# Altium schematic sheet [1]MainPCB.SchDoc
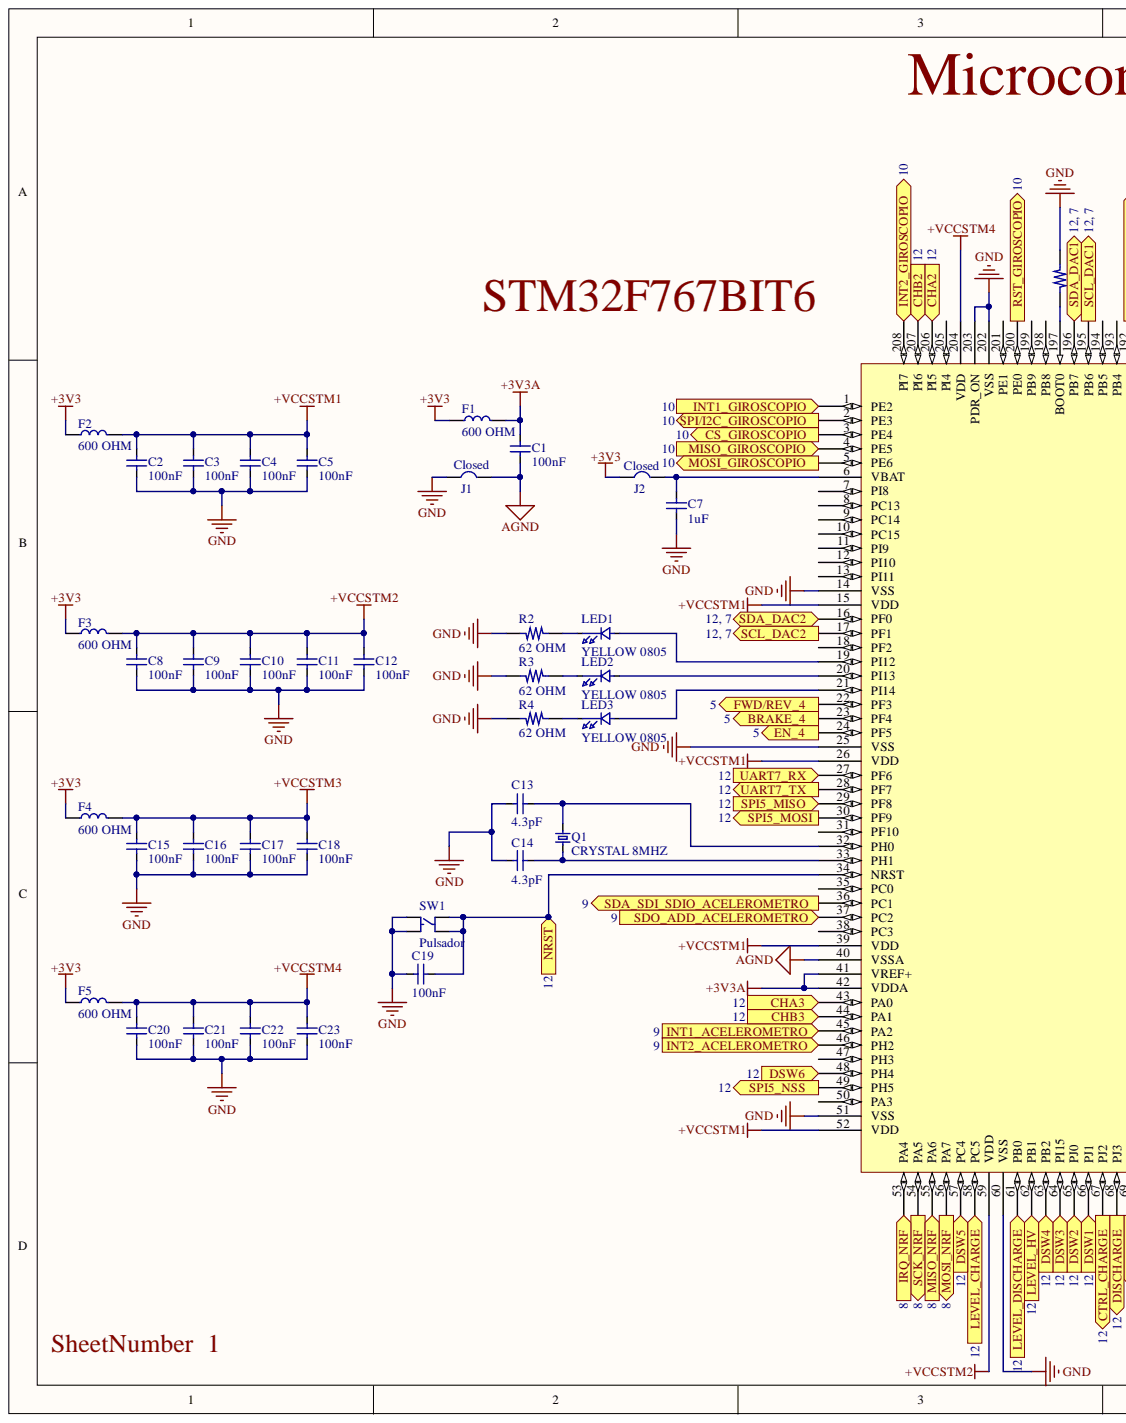
[diagram p0: [1]MainPCB.SchDoc, left]
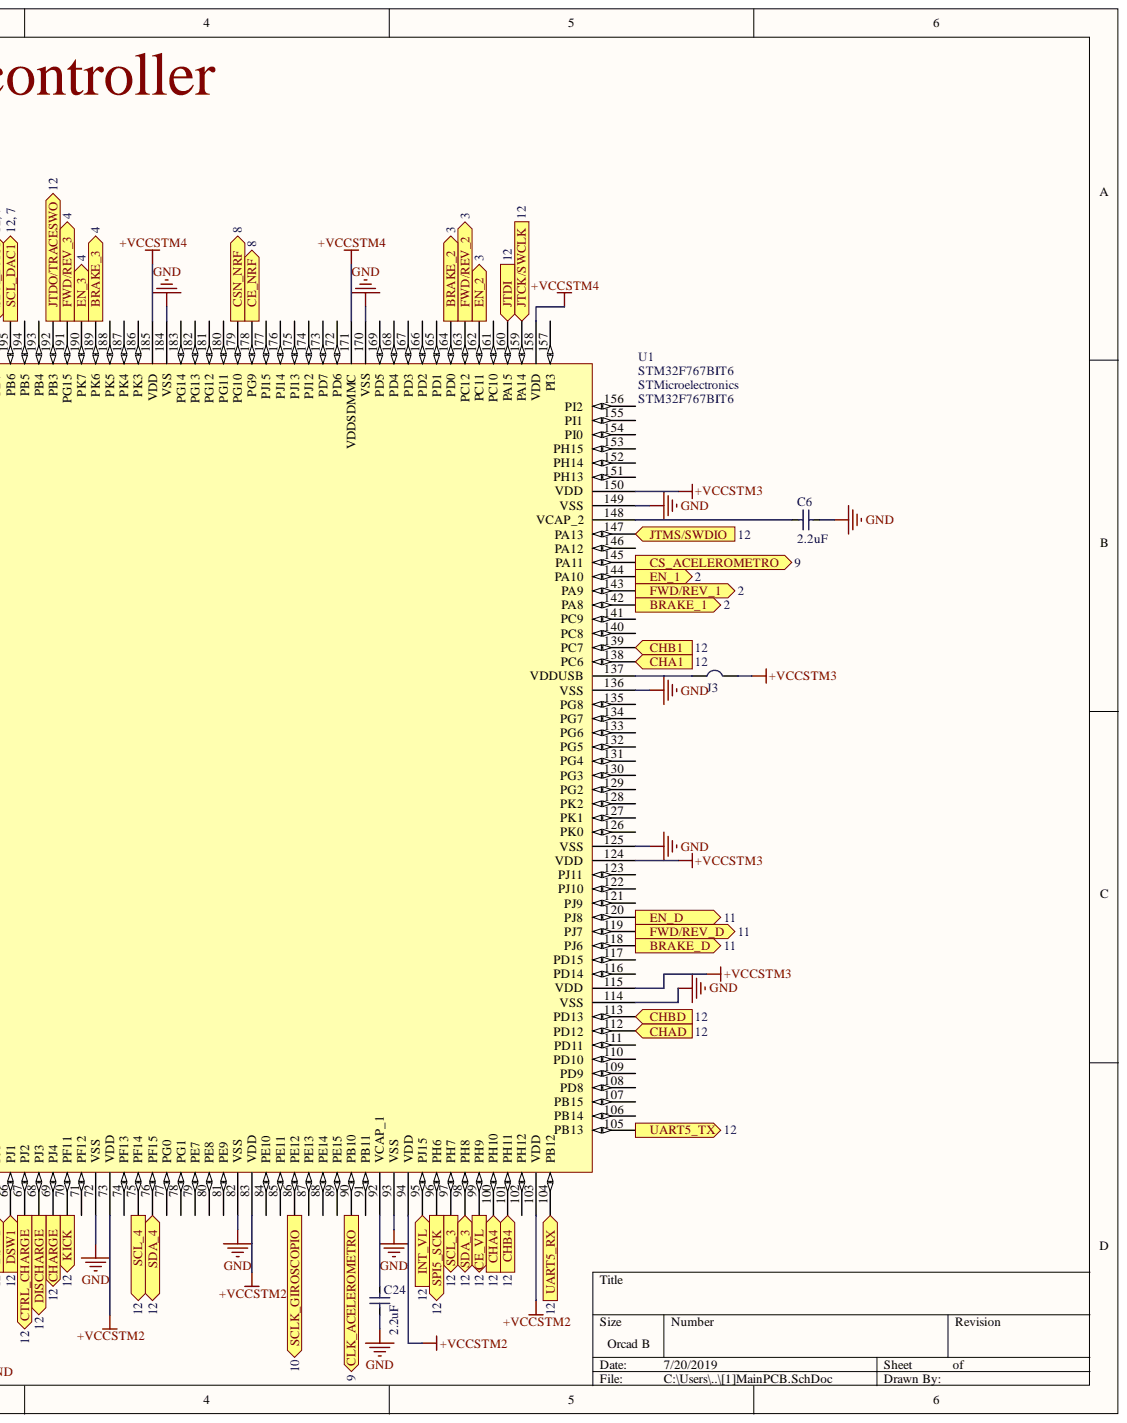
[diagram p1: [1]MainPCB.SchDoc, right]

## Parts
C1  100nF  [C0805]
C2  100nF  [C0805]
C3  100nF  [C0805]
C4  100nF  [C0805]
C5  100nF  [C0805]
C6  2.2uF  [C1206]
C7  1uF  [C0805]
C8  100nF  [C0805]
C9  100nF  [C0805]
C10  100nF  [C0805]
C11  100nF  [C0805]
C12  100nF  [C0805]
C13  4.3pF  [C0805]
C14  4.3pF  [C0805]
C15  100nF  [C0805]
C16  100nF  [C0805]
C17  100nF  [C0805]
C18  100nF  [C0805]
C19  100nF  [C0805]
C20  100nF  [C0805]
C21  100nF  [C0805]
C22  100nF  [C0805]
C23  100nF  [C0805]
C24  2.2uF  [C1206]
F1  600 OHM  [FB0805]
F2  600 OHM  [FB0805]
F3  600 OHM  [FB0805]
F4  600 OHM  [FB0805]
F5  600 OHM  [FB0805]
J1  Jumper  [Jumper]  (value not drawn)
J2  Jumper  [Jumper]  (value not drawn)
J3  Jumper  [Jumper]  (value not drawn)
LED1  YELLOW 0805  [LED0805]
LED2  YELLOW 0805  [LED0805]
LED3  YELLOW 0805  [LED0805]
Q1  CRYSTAL 8MHZ  [TXC 8MHZ Crystal]
R1  10k  [R0805]
R2  62 OHM  [R0805]
R3  62 OHM  [R0805]
R4  62 OHM  [R0805]
SW1  Pulsador  [SMT]
U1  STM32F767BIT6  Mfr 1: STMicroelectronics  [208LQFP]

## Ports
BRAKE_1, BRAKE_2, BRAKE_3, BRAKE_4, BRAKE_D, CE_NRF, CE_VL, CHA1, CHA2, CHA3, CHA4, CHAD, CHARGE, CHB1, CHB2, CHB3, CHB4, CHBD, CLK_ACELEROMETRO, CS_ACELEROMETRO, CS_GIROSCOPIO, CSN_NRF, CTRL_CHARGE, DISCHARGE, DSW1, DSW2, DSW3, DSW4, DSW5, DSW6, EN_1, EN_2, EN_3, EN_4, EN_D, FWD/REV_1, FWD/REV_2, FWD/REV_3, FWD/REV_4, FWD/REV_D, INT1_ACELEROMETRO, INT1_GIROSCOPIO, INT2_ACELEROMETRO, INT2_GIROSCOPIO, INT_VL, IRQ_NRF, JTCK/SWCLK, JTDI, JTDO/TRACESWO, JTMS/SWDIO, KICK, LEVEL_CHARGE, LEVEL_DISCHARGE, LEVEL_HV, MISO_GIROSCOPIO, MISO_NRF, MOSI_GIROSCOPIO, MOSI_NRF, NRST, RST_GIROSCOPIO, SCK_NRF, SCL_3, SCL_4, SCL_DAC1, SCL_DAC2, SCLK_GIROSCOPIO, SDA_3, SDA_4, SDA_DAC1, SDA_DAC2, SDA_SDI_SDIO_ACELEROMETRO, SDO_ADD_ACELEROMETRO, SPI5_MISO, SPI5_MOSI, SPI5_NSS, SPI5_SCK, SPI/I2C_GIROSCOPIO, UART5_RX, UART5_TX, UART7_RX, UART7_TX

## Nets
+3V3: F1.1 F2.1 F3.1 F4.1 F5.1 J2.1
+3V3A: C1.2 F1.2 U1.41(VREF+) U1.42(VDDA)
+VCCSTM1: C2.2 C3.2 C4.2 C5.2 F2.2 U1.15(VDD) U1.26(VDD) U1.39(VDD) U1.52(VDD)
+VCCSTM2: C8.2 C9.2 C10.2 C11.2 C12.2 F3.2 U1.59(VDD) U1.73(VDD) U1.83(VDD) U1.94(VDD) U1.103(VDD)
+VCCSTM3: C15.2 C16.2 C17.2 C18.2 F4.2 J3.2 U1.115(VDD) U1.124(VDD) U1.150(VDD)
+VCCSTM4: C20.2 C21.2 C22.2 C23.2 F5.2 U1.158(VDD) U1.171(VDDSDMMC) U1.185(VDD) U1.204(VDD)
AGND: C1.1 J1.2 U1.40(VSSA)
GND: C2.1 C3.1 C4.1 C5.1 C6.2 C7.1 C8.1 C9.1 C10.1 C11.1 C12.1 C13.1 C14.1 C15.1 C16.1 C17.1 C18.1 C19.1 C20.1 C21.1 C22.1 C23.1 C24.1 J1.1 R1.2 R2.1 R3.1 R4.1 SW1.1 SW1.2 U1.14(VSS) U1.25(VSS) U1.51(VSS) U1.60(VSS) U1.72(VSS) U1.82(VSS) U1.93(VSS) U1.114(VSS) U1.125(VSS) U1.136(VSS) U1.149(VSS) U1.170(VSS) U1.184(VSS) U1.202(VSS) U1.203(PDR_ON)
BRAKE_1: U1.142(PA8) ; port BRAKE_1
BRAKE_2: U1.164(PD0) ; port BRAKE_2
BRAKE_3: U1.189(PK6) ; port BRAKE_3
BRAKE_4: U1.23(PF4) ; port BRAKE_4
BRAKE_D: U1.118(PJ6) ; port BRAKE_D
CE_NRF: U1.178(PG9) ; port CE_NRF
CE_VL: U1.99(PH9) ; port CE_VL
CHA1: U1.138(PC6) ; port CHA1
CHA2: U1.206(PI5) ; port CHA2
CHA3: U1.43(PA0) ; port CHA3
CHA4: U1.100(PH10) ; port CHA4
CHAD: U1.112(PD12) ; port CHAD
CHARGE: U1.69(PJ4) ; port CHARGE
CHB1: U1.139(PC7) ; port CHB1
CHB2: U1.207(PI6) ; port CHB2
CHB3: U1.44(PA1) ; port CHB3
CHB4: U1.101(PH11) ; port CHB4
CHBD: U1.113(PD13) ; port CHBD
CLK_ACELEROMETRO: U1.90(PB10) ; port CLK_ACELEROMETRO
CS_ACELEROMETRO: U1.145(PA11) ; port CS_ACELEROMETRO
CS_GIROSCOPIO: U1.3(PE4) ; port CS_GIROSCOPIO
CSN_NRF: U1.179(PG10) ; port CSN_NRF
CTRL_CHARGE: U1.67(PJ2) ; port CTRL_CHARGE
DISCHARGE: U1.68(PJ3) ; port DISCHARGE
DSW1: U1.66(PJ1) ; port DSW1
DSW2: U1.65(PJ0) ; port DSW2
DSW3: U1.64(PI15) ; port DSW3
DSW4: U1.63(PB2) ; port DSW4
DSW5: U1.57(PC4) ; port DSW5
DSW6: U1.48(PH4) ; port DSW6
EN_1: U1.144(PA10) ; port EN_1
EN_2: U1.162(PC11) ; port EN_2
EN_3: U1.190(PK7) ; port EN_3
EN_4: U1.24(PF5) ; port EN_4
EN_D: U1.120(PJ8) ; port EN_D
FWD/REV_1: U1.143(PA9) ; port FWD/REV_1
FWD/REV_2: U1.163(PC12) ; port FWD/REV_2
FWD/REV_3: U1.191(PG15) ; port FWD/REV_3
FWD/REV_4: U1.22(PF3) ; port FWD/REV_4
FWD/REV_D: U1.119(PJ7) ; port FWD/REV_D
INT1_ACELEROMETRO: U1.45(PA2) ; port INT1_ACELEROMETRO
INT1_GIROSCOPIO: U1.1(PE2) ; port INT1_GIROSCOPIO
INT2_ACELEROMETRO: U1.46(PH2) ; port INT2_ACELEROMETRO
INT2_GIROSCOPIO: U1.208(PI7) ; port INT2_GIROSCOPIO
INT_VL: U1.95(PJ15) ; port INT_VL
IRQ_NRF: U1.53(PA4) ; port IRQ_NRF
JTCK/SWCLK: U1.159(PA14) ; port JTCK/SWCLK
JTDI: U1.160(PA15) ; port JTDI
JTDO/TRACESWO: U1.192(PB3) ; port JTDO/TRACESWO
JTMS/SWDIO: U1.147(PA13) ; port JTMS/SWDIO
KICK: U1.70(PF11) ; port KICK
LEVEL_CHARGE: U1.58(PC5) ; port LEVEL_CHARGE
LEVEL_DISCHARGE: U1.61(PB0) ; port LEVEL_DISCHARGE
LEVEL_HV: U1.62(PB1) ; port LEVEL_HV
MISO_GIROSCOPIO: U1.4(PE5) ; port MISO_GIROSCOPIO
MISO_NRF: U1.55(PA6) ; port MISO_NRF
MOSI_GIROSCOPIO: U1.5(PE6) ; port MOSI_GIROSCOPIO
MOSI_NRF: U1.56(PA7) ; port MOSI_NRF
NRST: C19.2 SW1.3 SW1.4 U1.34(NRST) ; port NRST
RST_GIROSCOPIO: U1.200(PE0) ; port RST_GIROSCOPIO
SCK_NRF: U1.54(PA5) ; port SCK_NRF
SCL_3: U1.97(PH7) ; port SCL_3
SCL_4: U1.75(PF14) ; port SCL_4
SCL_DAC1: U1.195(PB6) ; port SCL_DAC1
SCL_DAC2: U1.17(PF1) ; port SCL_DAC2
SCLK_GIROSCOPIO: U1.86(PE12) ; port SCLK_GIROSCOPIO
SDA_3: U1.98(PH8) ; port SDA_3
SDA_4: U1.76(PF15) ; port SDA_4
SDA_DAC1: U1.196(PB7) ; port SDA_DAC1
SDA_DAC2: U1.16(PF0) ; port SDA_DAC2
SDA_SDI_SDIO_ACELEROMETRO: U1.36(PC1) ; port SDA_SDI_SDIO_ACELEROMETRO
SDO_ADD_ACELEROMETRO: U1.37(PC2) ; port SDO_ADD_ACELEROMETRO
SPI5_MISO: U1.29(PF8) ; port SPI5_MISO
SPI5_MOSI: U1.30(PF9) ; port SPI5_MOSI
SPI5_NSS: U1.49(PH5) ; port SPI5_NSS
SPI5_SCK: U1.96(PH6) ; port SPI5_SCK
SPI/I2C_GIROSCOPIO: U1.2(PE3) ; port SPI/I2C_GIROSCOPIO
UART5_RX: U1.104(PB12) ; port UART5_RX
UART5_TX: U1.105(PB13) ; port UART5_TX
UART7_RX: U1.27(PF6) ; port UART7_RX
UART7_TX: U1.28(PF7) ; port UART7_TX
NetC6_1: C6.1 U1.148(VCAP_2)
NetC7_2: C7.2 J2.2 U1.6(VBAT)
NetC13_2: C13.2 Q1.2 U1.32(PH0)
NetC14_2: C14.2 Q1.1 U1.33(PH1)
NetC24_2: C24.2 U1.92(VCAP_1)
NetJ3_1: J3.1 U1.137(VDDUSB)
NetLED1_A: LED1.A U1.19(PI12)
NetLED1_K: LED1.K R2.2
NetLED2_A: LED2.A U1.20(PI13)
NetLED2_K: LED2.K R3.2
NetLED3_A: LED3.A U1.21(PI14)
NetLED3_K: LED3.K R4.2
NetR1_1: R1.1 U1.197(BOOT0)

Not connected: U1 7(PI8) 8(PC13) 9(PC14) 10(PC15) 11(PI9) 12(PI10) 13(PI11) 18(PF2) 31(PF10) 35(PC0) 38(PC3) 47(PH3) 50(PA3) 71(PF12) 74(PF13) 77(PG0) 78(PG1) 79(PE7) 80(PE8) 81(PE9) 84(PE10) 85(PE11) 87(PE13) 88(PE14) 89(PE15) 91(PB11) 102(PH12) 106(PB14) 107(PB15) 108(PD8) 109(PD9) 110(PD10) 111(PD11) 116(PD14) 117(PD15) 121(PJ9) 122(PJ10) 123(PJ11) 126(PK0) 127(PK1) 128(PK2) 129(PG2) 130(PG3) 131(PG4) 132(PG5) 133(PG6) 134(PG7) 135(PG8) 140(PC8) 141(PC9) 146(PA12) 151(PH13) 152(PH14) 153(PH15) 154(PI0) 155(PI1) 156(PI2) 157(PI3) 161(PC10) 165(PD1) 166(PD2) 167(PD3) 168(PD4) 169(PD5) 172(PD6) 173(PD7) 174(PJ12) 175(PJ13) 176(PJ14) 177(PJ15) 180(PG11) 181(PG12) 182(PG13) 183(PG14) 186(PK3) 187(PK4) 188(PK5) 193(PB4) 194(PB5) 198(PB8) 199(PB9) 201(PE1) 205(PI4)

## Library data of the parts
C1-C5 C8-C12 C15-C23 = CC0805ZRY5V9BB104: CAP CER 0.1UF 50V Y5V 0805; Mfr 1: Yageo; Component Kind: Standard; Library Name: Components.SchLib; Mfr 1 PN: CC0805ZRY5V9BB104
C6 C24 = CC1206KKX7R7BB225: CAP CER 2.2UF 16V X7R 1206; Mfr 1: Yageo; Component Kind: Standard; Library Name: Components.SchLib; Mfr 1 PN: CC1206KKX7R7BB225
C7 = UMK212BJ105KG-T: CAP CER 1UF 50V X5R 0805; Mfr 1: Taiyo Yuden; Component Kind: Standard; Library Name: Components.SchLib; Mfr 1 PN: UMK212BJ105KG-T
C13 C14 = 08051A4R3CAT2A: CAP CER 4.3PF 100V NP0 0805; Mfr 1: AVX Corporation; Component Kind: Standard; Library Name: Components.SchLib; Mfr 1 PN: 08051A4R3CAT2A
F1-F5 = MH2029-601Y: FERRITE BEAD 600 OHM 0805 1LN; Mfr 1: Bourns Inc.; Component Kind: Standard; Library Name: Components.SchLib; Mfr 1 PN: MH2029-601Y
LED1-LED3 = APT2012YC: LED YELLOW CLEAR CHIP SMD; Mfr 1: Kingbright; Component Kind: Standard; Library Name: Components.SchLib; Mfr 1 PN: APT2012YC
Q1 = AA-8.000MALV-T: CRYSTAL 8.0000MHZ 8PF SMD; Mfr 1: TXC CORPORATION; Component Kind: Standard; Library Name: Components.SchLib; Mfr 1 PN: AA-8.000MALV-T
R1 = RC0805FR-0710KL: RES SMD 10K OHM 1% 1/8W 0805; Mfr 1: Yageo; Component Kind: Standard; Library Name: Components.SchLib; Mfr 1 PN: RC0805FR-0710KL
R2-R4 = RR1220Q-620-D: RES SMD 62 OHM 0.5% 1/10W 0805; Mfr 1: Susumu; Component Kind: Standard; Library Name: Components.SchLib; Mfr 1 PN: RR1220Q-620-D
SW1 = PTS645SM43SMTR92 LFS: SWITCH TACTILE SPST-NO 0.05A 12V; Mfr 1: C&K; Component Kind: Standard; Library Name: Components.SchLib; Mfr 1 PN: PTS645SM43SMTR92 LFS
U1 = STM32F767BIT6: IC MCU 32BIT 2MB FLASH 208LQFP; Component Kind: Standard; Library Name: Components.SchLib; Mfr 1 PN: STM32F767BIT6
J1-J3 = Jumper: Component Kind: Standard; Library Name: Components.SchLib
